# Altium schematic sheet FlyWay_Sch.SchDoc(FlyWay_Sch)
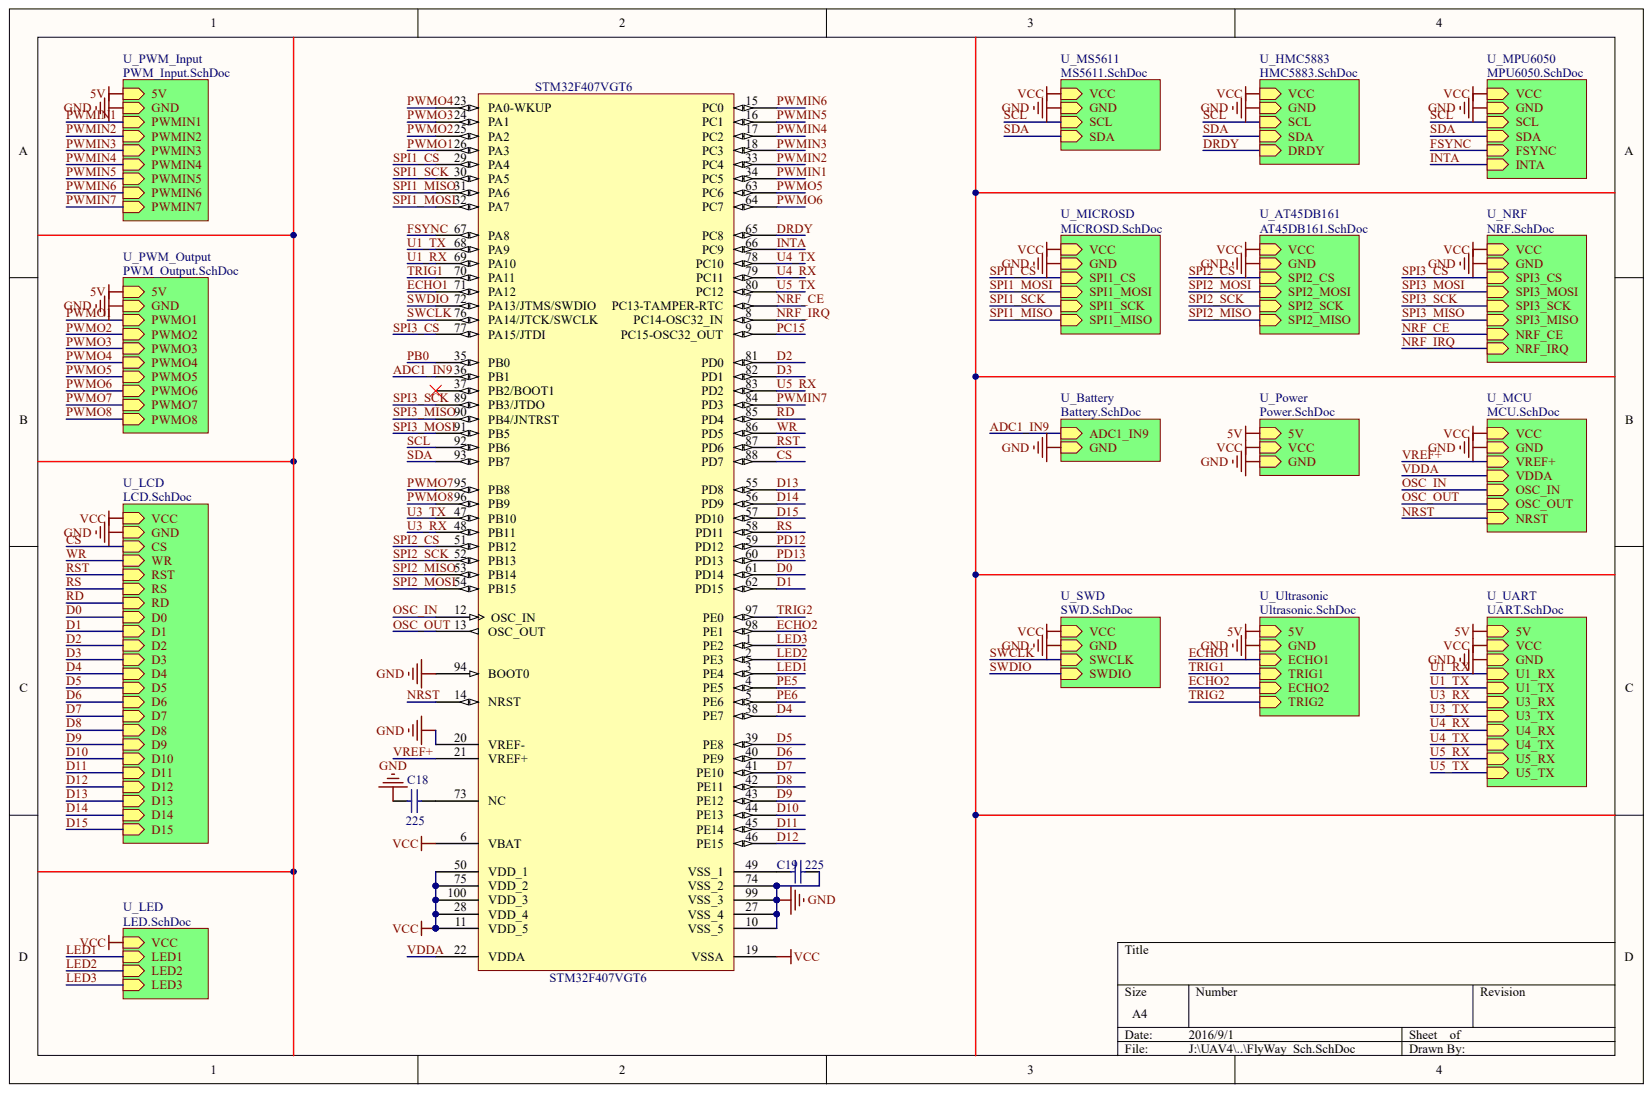
[diagram p0: FlyWay_Sch.SchDoc]

## Parts
C18  225
C19  225
STM32F407VGT6  STM32F407VGT6

## Nets
GND: C18.1 C19.2 STM32F407VGT6.10(VSS_5) STM32F407VGT6.20(VREF-) STM32F407VGT6.27(VSS_4) STM32F407VGT6.74(VSS_2) STM32F407VGT6.94(BOOT0) STM32F407VGT6.99(VSS_3)
VCC: STM32F407VGT6.6(VBAT) STM32F407VGT6.11(VDD_5) STM32F407VGT6.19(VSSA) STM32F407VGT6.28(VDD_4) STM32F407VGT6.50(VDD_1) STM32F407VGT6.75(VDD_2) STM32F407VGT6.100(VDD_3)
ADC1_IN9: STM32F407VGT6.36(PB1)
CS: STM32F407VGT6.88(PD7)
D0: STM32F407VGT6.61(PD14)
D1: STM32F407VGT6.62(PD15)
D2: STM32F407VGT6.81(PD0)
D3: STM32F407VGT6.82(PD1)
D4: STM32F407VGT6.38(PE7)
D5: STM32F407VGT6.39(PE8)
D6: STM32F407VGT6.40(PE9)
D7: STM32F407VGT6.41(PE10)
D8: STM32F407VGT6.42(PE11)
D9: STM32F407VGT6.43(PE12)
D10: STM32F407VGT6.44(PE13)
D11: STM32F407VGT6.45(PE14)
D12: STM32F407VGT6.46(PE15)
D13: STM32F407VGT6.55(PD8)
D14: STM32F407VGT6.56(PD9)
D15: STM32F407VGT6.57(PD10)
DRDY: STM32F407VGT6.65(PC8)
ECHO1: STM32F407VGT6.71(PA12)
ECHO2: STM32F407VGT6.98(PE1)
FSYNC: STM32F407VGT6.67(PA8)
INTA: STM32F407VGT6.66(PC9)
LED1: STM32F407VGT6.3(PE4)
LED2: STM32F407VGT6.2(PE3)
LED3: STM32F407VGT6.1(PE2)
NRF_CE: STM32F407VGT6.7(PC13-TAMPER-RTC)
NRF_IRQ: STM32F407VGT6.8(PC14-OSC32_IN)
NRST: STM32F407VGT6.14(NRST)
OSC_IN: STM32F407VGT6.12(OSC_IN)
OSC_OUT: STM32F407VGT6.13(OSC_OUT)
PB0: STM32F407VGT6.35(PB0)
PC15: STM32F407VGT6.9(PC15-OSC32_OUT)
PD12: STM32F407VGT6.59(PD12)
PD13: STM32F407VGT6.60(PD13)
PE5: STM32F407VGT6.4(PE5)
PE6: STM32F407VGT6.5(PE6)
PWMIN1: STM32F407VGT6.34(PC5)
PWMIN2: STM32F407VGT6.33(PC4)
PWMIN3: STM32F407VGT6.18(PC3)
PWMIN4: STM32F407VGT6.17(PC2)
PWMIN5: STM32F407VGT6.16(PC1)
PWMIN6: STM32F407VGT6.15(PC0)
PWMIN7: STM32F407VGT6.84(PD3)
PWMO1: STM32F407VGT6.26(PA3)
PWMO2: STM32F407VGT6.25(PA2)
PWMO3: STM32F407VGT6.24(PA1)
PWMO4: STM32F407VGT6.23(PA0-WKUP)
PWMO5: STM32F407VGT6.63(PC6)
PWMO6: STM32F407VGT6.64(PC7)
PWMO7: STM32F407VGT6.95(PB8)
PWMO8: STM32F407VGT6.96(PB9)
RD: STM32F407VGT6.85(PD4)
RS: STM32F407VGT6.58(PD11)
RST: STM32F407VGT6.87(PD6)
SCL: STM32F407VGT6.92(PB6)
SDA: STM32F407VGT6.93(PB7)
SPI1_CS: STM32F407VGT6.29(PA4)
SPI1_MISO: STM32F407VGT6.31(PA6)
SPI1_MOSI: STM32F407VGT6.32(PA7)
SPI1_SCK: STM32F407VGT6.30(PA5)
SPI2_CS: STM32F407VGT6.51(PB12)
SPI2_MISO: STM32F407VGT6.53(PB14)
SPI2_MOSI: STM32F407VGT6.54(PB15)
SPI2_SCK: STM32F407VGT6.52(PB13)
SPI3_CS: STM32F407VGT6.77(PA15/JTDI)
SPI3_MISO: STM32F407VGT6.90(PB4/JNTRST)
SPI3_MOSI: STM32F407VGT6.91(PB5)
SPI3_SCK: STM32F407VGT6.89(PB3/JTDO)
SWCLK: STM32F407VGT6.76(PA14/JTCK/SWCLK)
SWDIO: STM32F407VGT6.72(PA13/JTMS/SWDIO)
TRIG1: STM32F407VGT6.70(PA11)
TRIG2: STM32F407VGT6.97(PE0)
U1_RX: STM32F407VGT6.69(PA10)
U1_TX: STM32F407VGT6.68(PA9)
U3_RX: STM32F407VGT6.48(PB11)
U3_TX: STM32F407VGT6.47(PB10)
U4_RX: STM32F407VGT6.79(PC11)
U4_TX: STM32F407VGT6.78(PC10)
U5_RX: STM32F407VGT6.83(PD2)
U5_TX: STM32F407VGT6.80(PC12)
VDDA: STM32F407VGT6.22(VDDA)
VREF+: STM32F407VGT6.21(VREF+)
WR: STM32F407VGT6.86(PD5)
NetC18_2: C18.2 STM32F407VGT6.73(NC)
NetC19_1: C19.1 STM32F407VGT6.49(VSS_1)
NetSTM32F407VGT6_37: STM32F407VGT6.37(PB2/BOOT1)
5V:
N00041:
N00042:

## Library data of the parts
C18 C19 = (no symbol name): Value: 2.2uF; Published: 8-Jun-2000; LatestRevisionDate: 17-Jul-2002; LatestRevisionNote: Re-released for DXP Platform.; PackageReference: RAD-0.3; PackageDescription: Radial Cap, Thru-Hole; 2 Leads; 0.3 in Pin Spacing; Publisher: Altium Limited
STM32F407VGT6 = (no symbol name): Published: 30-May-2008; DatasheetVersion: rev6, Mar-2008; PackageVersion: Mar-2008; PackageReference: LQFP100; PackageDescription: 100-Pin Low-Profile Quad Flat Pack, 14 x 14 mm Body, 0.5 mm Pitch (LQFP100); ComponentLink1Description: Manufacturer Link; ComponentLink1URL: www.st.com/stm32; ComponentLink2Description: Datasheet; ComponentLink2URL: http://www.st.com/stonline/products/literature/ds/13587.pdf; Publisher: Altium Limited
